# Altium schematic sheet 10)Connectors.SchDoc(10)Connectors)
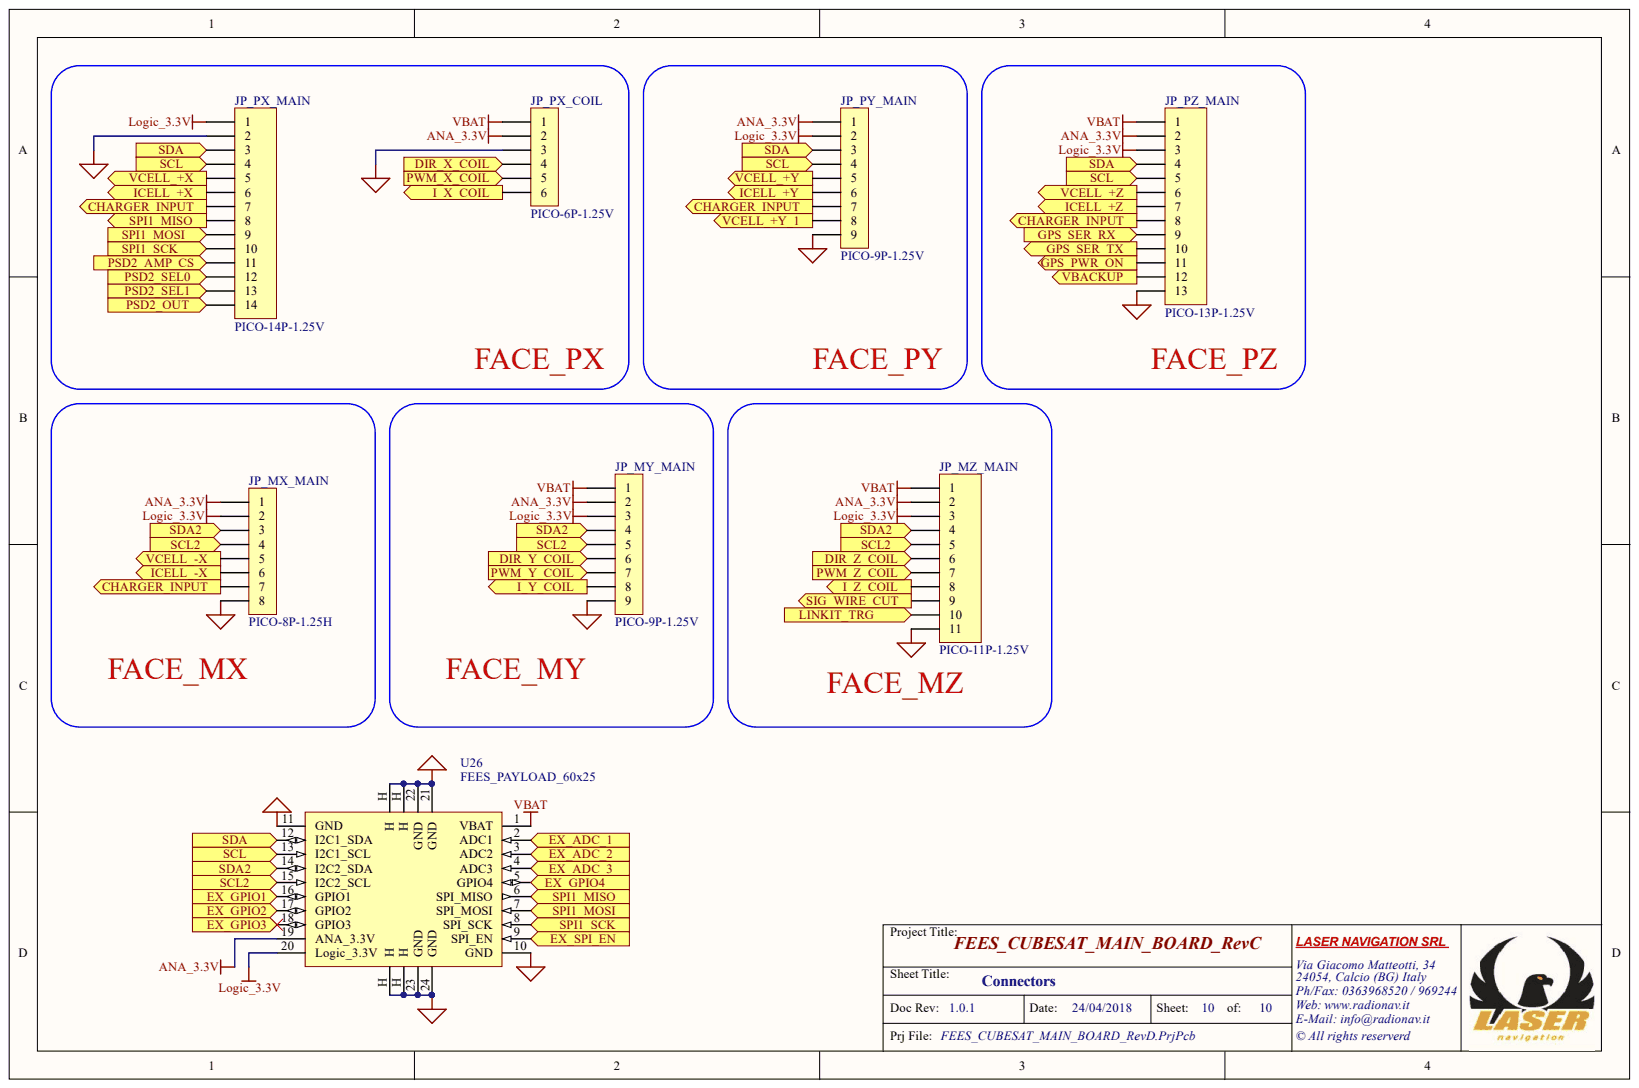
[diagram p0: 10)Connectors.SchDoc]

## Parts
JP_MX_MAIN  PICO-8P-1.25H  [PICO-8P-1.25H]
JP_MY_MAIN  PICO-9P-1.25V  [PICO-9P-1.25V]
JP_MZ_MAIN  PICO-11P-1.25V  [PICO-11P-1.25V]
JP_PX_COIL  PICO-6P-1.25V  [PICO-6P-1.25V]
JP_PX_MAIN  PICO-14P-1.25V  [PICO-14P-1.25V]
JP_PY_MAIN  PICO-9P-1.25V  [PICO-9P-1.25V]
JP_PZ_MAIN  PICO-13P-1.25V  [PICO-13P-1.25V]
U26  FEES_PAYLOAD_60x25  [FEES_PAYLOAD_60x25]

## Ports
CHARGER_INPUT, DIR_X_COIL, DIR_Y_COIL, DIR_Z_COIL, EX_ADC_1, EX_ADC_2, EX_ADC_3, EX_GPIO1, EX_GPIO2, EX_GPIO3, EX_GPIO4, EX_SPI_EN, GPS_PWR_ON, GPS_SER_RX, GPS_SER_TX, I_X_COIL, I_Y_COIL, I_Z_COIL, ICELL_+X, ICELL_+Y, ICELL_+Z, ICELL_-X, LINKIT_TRG, PSD2_AMP_CS, PSD2_OUT, PSD2_SEL0, PSD2_SEL1, PWM_X_COIL, PWM_Y_COIL, PWM_Z_COIL, SCL, SCL2, SDA, SDA2, SIG_WIRE_CUT, SPI1_MISO, SPI1_MOSI, SPI1_SCK, VBACKUP, VCELL_+X, VCELL_+Y, VCELL_+Y_1, VCELL_+Z, VCELL_-X

## Nets
ANA_3.3V: JP_MX_MAIN.1 JP_MY_MAIN.2 JP_MZ_MAIN.2 JP_PX_COIL.2 JP_PY_MAIN.1 JP_PZ_MAIN.2 U26.19(ANA_3.3V)
GND: JP_MX_MAIN.8 JP_MY_MAIN.9 JP_MZ_MAIN.11 JP_PX_COIL.3 JP_PX_MAIN.2 JP_PY_MAIN.9 JP_PZ_MAIN.13 U26.10(GND) U26.11(GND) U26.21(GND) U26.22(GND) U26.23(GND) U26.24(GND) U26.H
LOGIC_3.3V: JP_MX_MAIN.2 JP_MY_MAIN.3 JP_MZ_MAIN.3 JP_PX_MAIN.1 JP_PY_MAIN.2 JP_PZ_MAIN.3 U26.20(Logic_3.3V)
VBAT: JP_MY_MAIN.1 JP_MZ_MAIN.1 JP_PX_COIL.1 JP_PZ_MAIN.1 U26.1(VBAT)
CHARGER_INPUT: JP_MX_MAIN.7 JP_PX_MAIN.7 JP_PY_MAIN.7 JP_PZ_MAIN.8 ; port CHARGER_INPUT
DIR_X_COIL: JP_PX_COIL.4 ; port DIR_X_COIL
DIR_Y_COIL: JP_MY_MAIN.6 ; port DIR_Y_COIL
DIR_Z_COIL: JP_MZ_MAIN.6 ; port DIR_Z_COIL
EX_ADC_1: U26.2(ADC1) ; port EX_ADC_1
EX_ADC_2: U26.3(ADC2) ; port EX_ADC_2
EX_ADC_3: U26.4(ADC3) ; port EX_ADC_3
EX_GPIO1: U26.16(GPIO1) ; port EX_GPIO1
EX_GPIO2: U26.17(GPIO2) ; port EX_GPIO2
EX_GPIO3: U26.18(GPIO3) ; port EX_GPIO3
EX_GPIO4: U26.5(GPIO4) ; port EX_GPIO4
EX_SPI_EN: U26.9(SPI_EN) ; port EX_SPI_EN
GPS_PWR_ON: JP_PZ_MAIN.11 ; port GPS_PWR_ON
GPS_SER_RX: JP_PZ_MAIN.9 ; port GPS_SER_RX
GPS_SER_TX: JP_PZ_MAIN.10 ; port GPS_SER_TX
I_X_COIL: JP_PX_COIL.6 ; port I_X_COIL
I_Y_COIL: JP_MY_MAIN.8 ; port I_Y_COIL
I_Z_COIL: JP_MZ_MAIN.8 ; port I_Z_COIL
ICELL_+Y: JP_PY_MAIN.6 ; port ICELL_+Y
ICELL_+Z: JP_PZ_MAIN.7 ; port ICELL_+Z
LINKIT_TRG: JP_MZ_MAIN.10 ; port LINKIT_TRG
PSD2_AMP_CS: JP_PX_MAIN.11 ; port PSD2_AMP_CS
PSD2_OUT: JP_PX_MAIN.14 ; port PSD2_OUT
PSD2_SEL0: JP_PX_MAIN.12 ; port PSD2_SEL0
PSD2_SEL1: JP_PX_MAIN.13 ; port PSD2_SEL1
PWM_X_COIL: JP_PX_COIL.5 ; port PWM_X_COIL
PWM_Y_COIL: JP_MY_MAIN.7 ; port PWM_Y_COIL
PWM_Z_COIL: JP_MZ_MAIN.7 ; port PWM_Z_COIL
SCL: JP_PX_MAIN.4 JP_PY_MAIN.4 JP_PZ_MAIN.5 U26.13(I2C1_SCL) ; port SCL
SCL2: JP_MX_MAIN.4 JP_MY_MAIN.5 JP_MZ_MAIN.5 U26.15(I2C2_SCL) ; port SCL2
SDA: JP_PX_MAIN.3 JP_PY_MAIN.3 JP_PZ_MAIN.4 U26.12(I2C1_SDA) ; port SDA
SDA2: JP_MX_MAIN.3 JP_MY_MAIN.4 JP_MZ_MAIN.4 U26.14(I2C2_SDA) ; port SDA2
SIG_WIRE_CUT: JP_MZ_MAIN.9 ; port SIG_WIRE_CUT
SPI1_MISO: JP_PX_MAIN.8 U26.6(SPI_MISO) ; port SPI1_MISO
SPI1_MOSI: JP_PX_MAIN.9 U26.7(SPI_MOSI) ; port SPI1_MOSI
SPI1_SCK: JP_PX_MAIN.10 U26.8(SPI_SCK) ; port SPI1_SCK
VBACKUP: JP_PZ_MAIN.12 ; port VBACKUP
VCELL_+Y: JP_PY_MAIN.5 ; port VCELL_+Y
VCELL_+Y_1: JP_PY_MAIN.8 ; port VCELL_+Y_1
VCELL_+Z: JP_PZ_MAIN.6 ; port VCELL_+Z
NetJP_MX_MAIN_5: JP_MX_MAIN.5
NetJP_MX_MAIN_6: JP_MX_MAIN.6
NetJP_PX_MAIN_5: JP_PX_MAIN.5
NetJP_PX_MAIN_6: JP_PX_MAIN.6

## Library data of the parts
JP_MX_MAIN = CON8: Connector; 1.Part Number: 53261-0871; 2.Manufacturer: Molex, LLC; 3.Description: CONN HEADER 8POS 1.25MM R/A SMD; 5.Mounting: Y; 4.Supplier: Digikey WM7626CT-ND; Component Kind: Standard; Library Name: User Sch Library.SchLib
JP_MY_MAIN JP_PY_MAIN = CON9: Connector; 1.Part Number: 53398-0971; 2.Manufacturer: Molex, LLC; 3.Description: CONN HEADER 9POS 1.25MM VERT SMD; 5.Mounting: Y; 4.Supplier: Digikey  WM7613CT-ND; Component Kind: Standard; Library Name: User Sch Library.SchLib
JP_MZ_MAIN = CON11: Connector; 1.Part Number: 53398-1171; 2.Manufacturer: Molex, LLC; 3.Description: CONN HEADER 11POS 1.25MM VERT SMD; 5.Mounting: Y; 4.Supplier: Digikey WM7615CT-ND; Component Kind: Standard; Library Name: User Sch Library.SchLib
JP_PX_COIL = CON6: Connector; 1.Part Number: 53398-0671; 2.Manufacturer: Molex, LLC; 3.Description: CONN HEADER 6POS 1.25MM VERT SMD; 5.Mounting: Y; 4.Supplier: Digikey WM7610CT-ND; Component Kind: Standard; Library Name: User Sch Library.SchLib
JP_PX_MAIN = CON14: Connector; 1.Part Number: 53398-1471; 2.Manufacturer: Molex, LLC; 3.Description: CONN HEADER 14POS 1.25MM VERT SMD; 5.Mounting: Y; 4.Supplier: Digikey WM7618CT-ND; Component Kind: Standard; Library Name: User Sch Library.SchLib
JP_PZ_MAIN = CON13: Connector; 1.Part Number: 53398-1371; 2.Manufacturer: Molex, LLC; 3.Description: CONN HEADER 13POS 1.25MM VERT SMD; 5.Mounting: Y; 4.Supplier: Digikey WM7617CT-ND; Component Kind: Standard; Library Name: User Sch Library.SchLib
U26 = FEES_PAYLOAD_60x25: Cpu Board Laser Navigation; Component Kind: Standard; Library Name: User Sch Library.SchLib
